# Altium schematic sheet 100_User interface.SchDoc
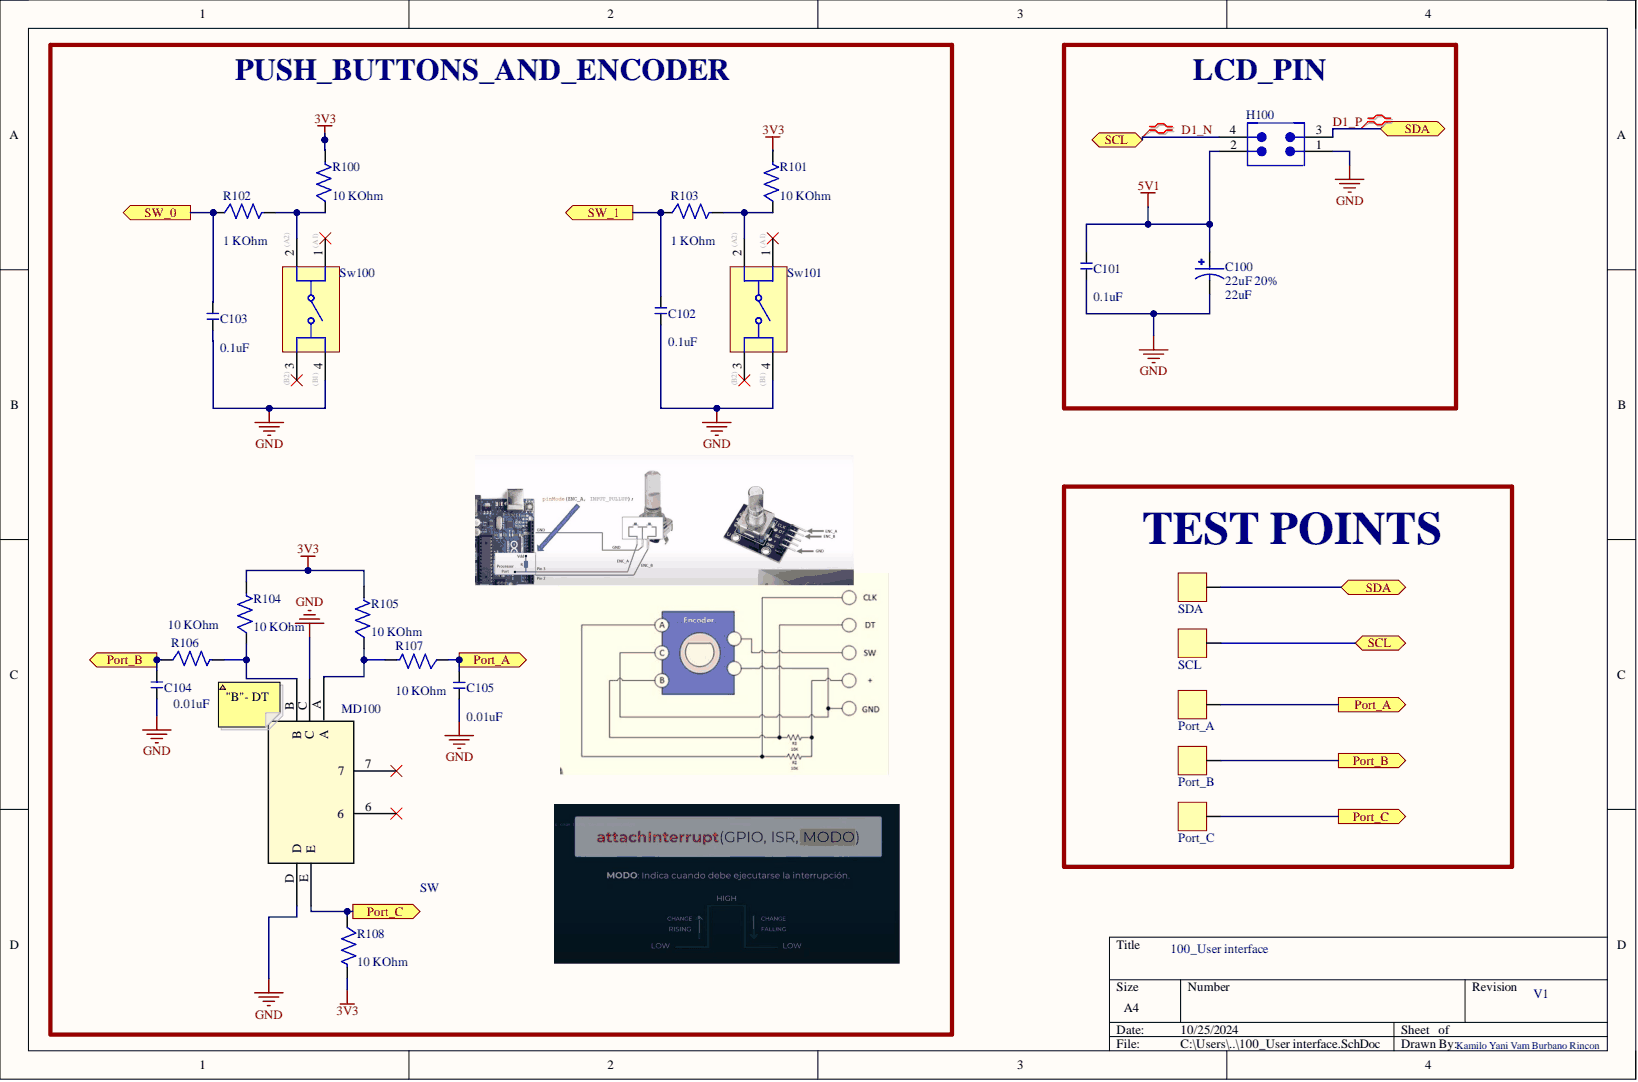
[diagram p0: 100_User interface.SchDoc]

## Parts
C100  22uF 20%  value 22uF  [CAP_THT_RADIAL_2]
C101  value 0.1uF  [CAP_THT_3.0mm]  (hidden comment: 0.1uF 20%)
C102  value 0.1uF  [CAP_THT_3.0mm]  (hidden comment: 0.1uF 20%)
C103  value 0.1uF  [CAP_THT_3.0mm]  (hidden comment: 0.1uF 20%)
C104  value 0.01uF  [CAP_THT_3.0mm]  (hidden comment: 0.01uF 20%)
C105  value 0.01uF  [CAP_THT_3.0mm]  (hidden comment: 0.01uF 20%)
H100  H_2x2_THT_2.54mm_Header  [CON_2x2_THT_2.54mm]  (value not drawn)
MD100  EC11-SW-E  [MOD_Encoder_EC11]  (value not drawn)
Port_A  TP_SMT_30mil  [TP_SMT_30mil]  (value not drawn)
Port_B  TP_SMT_30mil  [TP_SMT_30mil]  (value not drawn)
Port_C  TP_SMT_30mil  [TP_SMT_30mil]  (value not drawn)
R100  value 10 KOhm  [Axial]  (hidden comment: 10 KOhm 1/4W)
R101  value 10 KOhm  [Axial]  (hidden comment: 10 KOhm 1/4W)
R102  value 1 KOhm  [Axial]  (hidden comment: 1 KOhms)
R103  value 1 KOhm  [Axial]  (hidden comment: 1 KOhms)
R104  value 10 KOhm  [Axial]  (hidden comment: 10 KOhm 1/4W)
R105  value 10 KOhm  [Axial]  (hidden comment: 10 KOhm 1/4W)
R106  value 10 KOhm  [Axial]  (hidden comment: 10 KOhm 1/4W)
R107  value 10 KOhm  [Axial]  (hidden comment: 10 KOhm 1/4W)
R108  value 10 KOhm  [Axial]  (hidden comment: 10 KOhm 1/4W)
SCL  TP_SMT_30mil  [TP_SMT_30mil]  (value not drawn)
SDA  TP_SMT_30mil  [TP_SMT_30mil]  (value not drawn)
Sw100  SW-054  [Sw_PUSH_2Pos_12mmx12mmx5mm]  (value not drawn)
Sw101  SW-054  [Sw_PUSH_2Pos_12mmx12mmx5mm]  (value not drawn)

## Ports
Port_A, Port_B, Port_C, SCL, SDA, SW_0, SW_1

## Nets
3V3: R100.2 R101.2 R104.2 R105.2 R108.2
5V1: C100.P C101.2 H100.2
GND: C100.N C101.1 C102.1 C103.1 C104.1 C105.1 H100.1 MD100.C MD100.D Sw100.B1 Sw101.B1
D1_N: H100.4 SCL.1 ; port SCL
D1_P: H100.3 SDA.1 ; port SDA
Port_A: C105.2 Port_A.1 R107.1 ; port Port_A
Port_B: C104.2 Port_B.1 R106.1 ; port Port_B
Port_C: MD100.E Port_C.1 R108.1 ; port Port_C
SW_0: C103.2 R102.1 ; port SW_0
SW_1: C102.2 R103.1 ; port SW_1
NetMD100_A: MD100.A R105.1 R107.2
NetMD100_B: MD100.B R104.1 R106.2
NetR100_1: R100.1 R102.2 Sw100.A2
NetR101_1: R101.1 R103.2 Sw101.A2

Not connected: MD100 6 7; Sw100 A1 B2; Sw101 A1 B2

## Library data of the parts
C100 = Cap_22uF_16V_THT: 22uF   ±20% 50V Electrolict Capacitor Y5V; Component Kind: Standard; ComponentLink1Description: VISTRONICA; Last modified date: 2024055; Library Name: Library_laser.IntLib; Supplier 1: VISTRONICA; Last modified by: K-Y.Burbano
C101-C103 = Cap_0.1uF_50V_THT: 0.1uF   ±20% 50V Ceramic Capacitor Y5V; Component Kind: Standard; ComponentLink1Description: VISTRONICA; ComponentLink1URL: https://www.vistronica.com/componentes-pasivos/capacitores/condensador-ceramico-104-01uf-100nf--detail.html; Last modified date: 2024055; Supplier 1: VISTRONICA; Last modified by: K-Y.Burbano; Library Name: Library_Kam_ilo.IntLib
C104 C105 = Cap_0.01uF_50V_THT: 0.01uF   ±20% 50V Ceramic Capacitor; Component Kind: Standard; ComponentLink1Description: Digi-Key; ComponentLink1URL: https://www.didacticaselectronicas.com/index.php/componentes-pasivos/capacitores/ceramicos/cc0-01uf50v-detail; Last modified date: 2024055; Supplier 1: Electronica i+d; Last modified by: K-Y.Burbano; Library Name: Library_Kam_ilo.IntLib
H100 = H_2x2_THT_2.54mm_Header: CONN HEADER THT 4POS 2.54MM; Component Kind: Standard; ComponentLink1Description: Electronica i+d; ComponentLink1URL: https://www.didacticaselectronicas.com/index.php/suiches-y-conectores/headers/HD40X2P-M-L-detail; Last modified date: 2024056; Supplier 1: Electronica i+d; Last modified by: K-Y.Burbano; Library Name: Library_Kam_ilo.IntLib
MD100 = Mod_ENCODER_EC11: 20 pasos de 18 grados ±2 grados , 20 pulsos/360 , Longitud del eje: 20mm, 5V, 5mA Máx.; Component Kind: Standard; ComponentLink1Description: Electronica i+d; ComponentLink1URL: https://www.didacticaselectronicas.com/index.php/sensores/encoders/ec11-sw-e-detail; Last modified date: 2024055; Supplier 1: Electronica i+d; Last modified by: K-Y.Burbano; Library Name: Library_Kam_ilo.IntLib
Port_A Port_B Port_C SCL SDA = TP_SMT_30mil: Test point 30mil hole size; Component Kind: Standard; ComponentLink1Description: Digi-Key; Last modified date: 20231205; Supplier 1: Digi-key; Last modified by: K-Y.Burbano; Library Name: Library_Kam_ilo.IntLib
R100 R101 R104 R106 R108 = Res_10KOhm_TH_1/4W: 10 kOhms ±1% 0.25W, 1/4W Through Hole Resistor Axial Metal Film; Component Kind: Standard; ComponentLink1Description: Digi-Key; ComponentLink1URL: https://www.didacticaselectronicas.com/index.php/componentes-pasivos/resistencias/through-hole/rp-10k-1-4w-detail; Last modified date: 2024055; Supplier 1: Electronica i+d; Last modified by: K-Y.Burbano; Library Name: Library_Kam_ilo.IntLib
R102 R103 = Res_1KOhm_THT_1/4W: 1 kOhms ±1% 0.25W, 1/4W Through Hole Resistor Axial Metal Film; Component Kind: Standard; ComponentLink1Description: Electronica i+d; ComponentLink1URL: https://www.didacticaselectronicas.com/index.php/componentes-pasivos/resistencias/through-hole/rp-1k-1-4w-detail; Last modified date: 2024055; Supplier 1: Electronica i+d; Last modified by: K-Y.Burbano; Library Name: Library_Kam_ilo.IntLib
R105 R107 = Res_10KOhm_TH_1/4W: 10 kOhms ±1% 0.25W, 1/4W Through Hole Resistor Axial Metal Film; Value: 10 KOhm; Component Kind: Standard; ComponentLink1Description: Digi-Key; ComponentLink1URL: https://www.didacticaselectronicas.com/index.php/componentes-pasivos/resistencias/through-hole/rp-10k-1-4w-detail; Last modified date: 2024055; Supplier 1: Electronica i+d; Last modified by: K-Y.Burbano; Library Name: Library_Kam_ilo.IntLib
Sw100 Sw101 = Sw_PUSH_12mmx12mmx5mm: SWITCH TACTILE SW-054 50mA 12V; Component Kind: Standard; ComponentLink1Description: Digi-Key; ComponentLink1URL: https://www.didacticaselectronicas.com/index.php/suiches-y-conectores/suiches/pulsadores/sw-054-detail; Last modified date: 2024055; Supplier 1: Electronica i+d; Last modified by: K-Y.Burbano; Library Name: Library_Kam_ilo.IntLib
